# Altium schematic sheet Amplifier.SchDoc
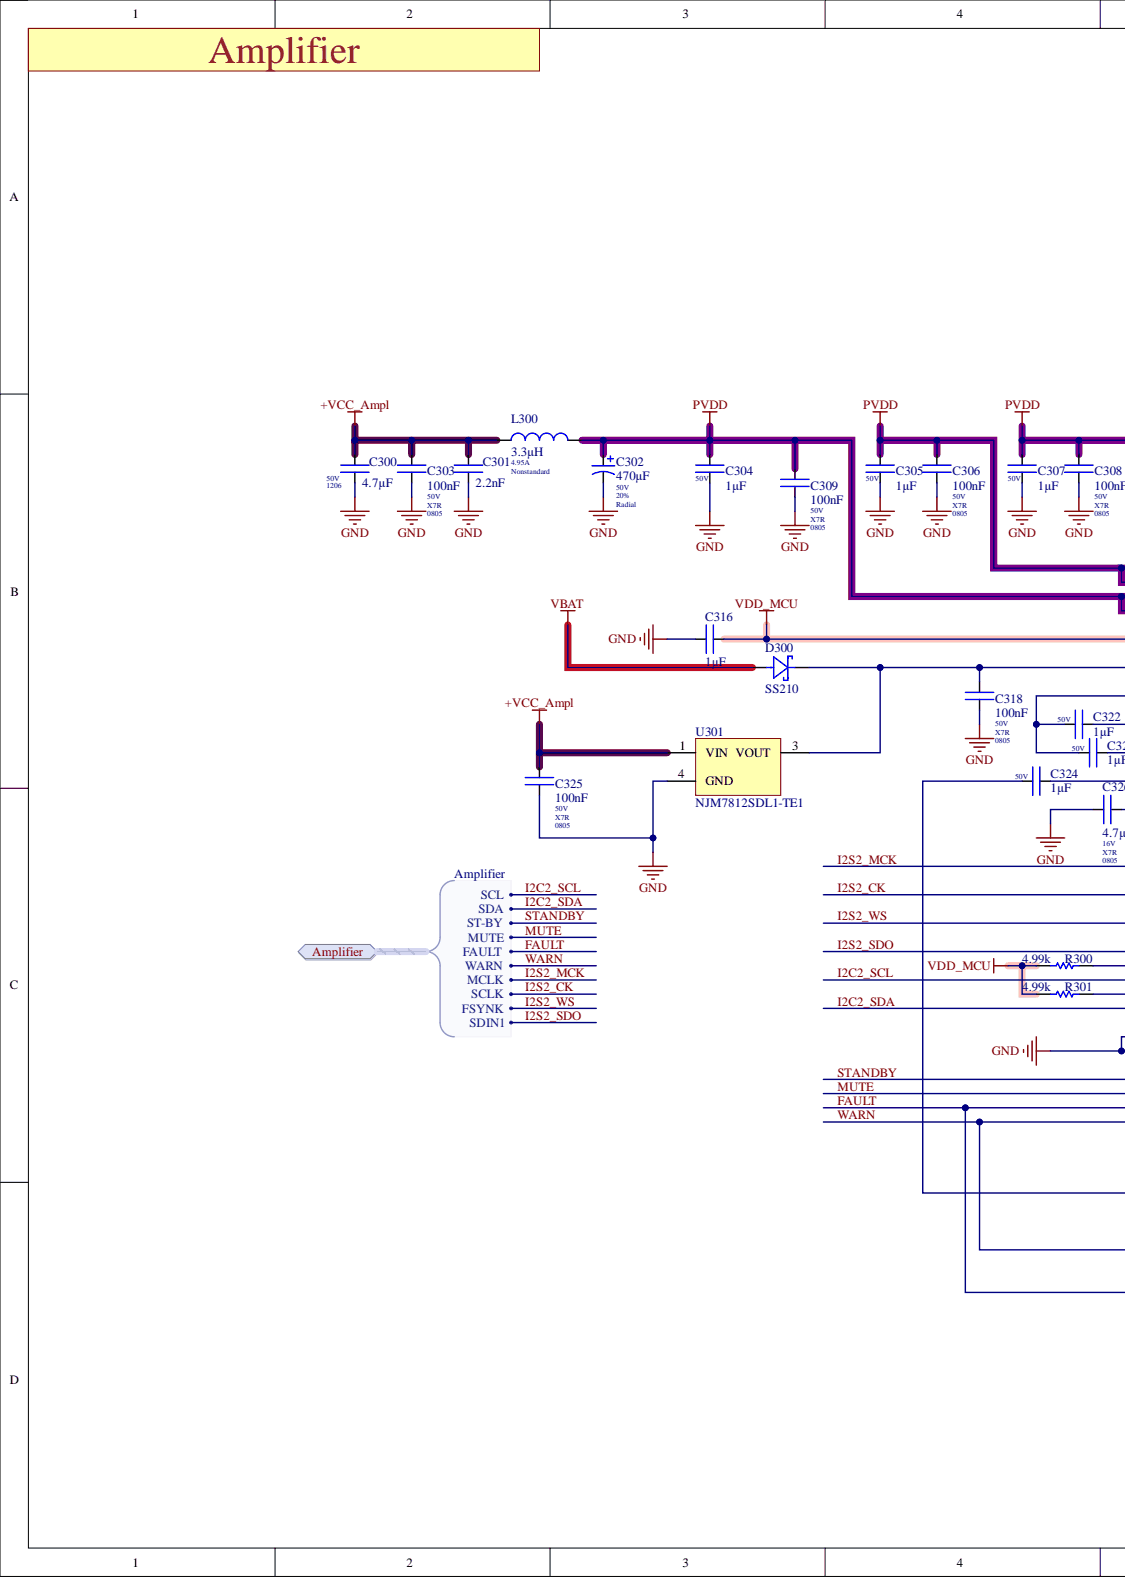
[diagram p0: Amplifier.SchDoc, left]
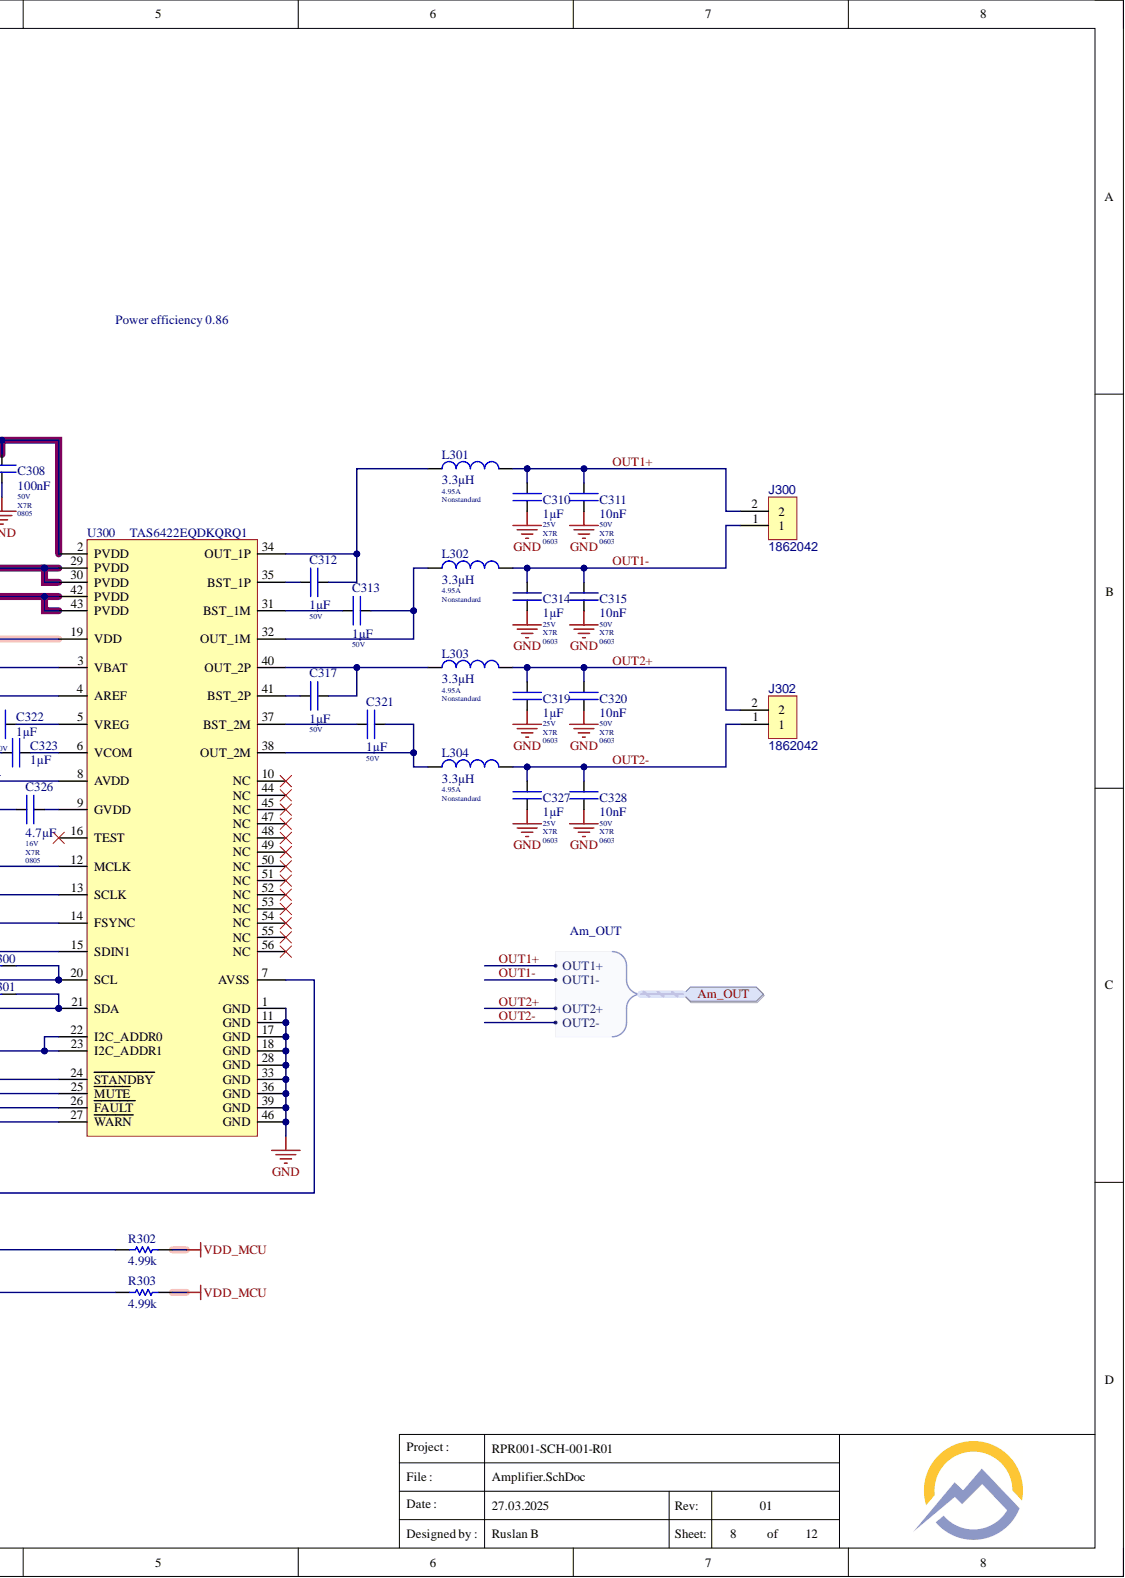
[diagram p1: Amplifier.SchDoc, right]

## Parts
C300  value 4.7µF  Case/Package: 1206  Voltage Rating: 50V  [B_Cap_1206_KEM]  (hidden comment: CL31B475KBHNNNE)
C301  value 2.2nF  [B_Cap_0402_KEM]  (hidden comment: CC0402JRX7R9BB222)
C302  value 470µF  Case/Package: Radial  Tolerance: 20%  Voltage Rating: 50V  [EMVA500ARA471MKG5S]  (hidden comment: EMVA500ARA471MKG5S)
C303  value 100nF  Case/Package: 0805  Dielectric: X7R  Voltage Rating: 50V  [B_Cap_0805_KEM]  (hidden comment: CC0805KRX7R9BB104)
C304  value 1µF  Voltage Rating: 50V  [B_Cap_0805_KEM]  (hidden comment: CL21B105KBFNNNG)
C305  value 1µF  Voltage Rating: 50V  [B_Cap_0805_KEM]  (hidden comment: CL21B105KBFNNNG)
C306  value 100nF  Case/Package: 0805  Dielectric: X7R  Voltage Rating: 50V  [B_Cap_0805_KEM]  (hidden comment: CC0805KRX7R9BB104)
C307  value 1µF  Voltage Rating: 50V  [B_Cap_0805_KEM]  (hidden comment: CL21B105KBFNNNG)
C308  value 100nF  Case/Package: 0805  Dielectric: X7R  Voltage Rating: 50V  [B_Cap_0805_KEM]  (hidden comment: CC0805KRX7R9BB104)
C309  value 100nF  Case/Package: 0805  Dielectric: X7R  Voltage Rating: 50V  [B_Cap_0805_KEM]  (hidden comment: CC0805KRX7R9BB104)
C310  value 1µF  Case/Package: 0603  Dielectric: X7R  Voltage Rating: 25V  [B_Cap_0603_KEM]  (hidden comment: CL10B105KA8NNNC)
C311  value 10nF  Case/Package: 0603  Dielectric: X7R  Voltage Rating: 50V  [B_Cap_0603_KEM]  (hidden comment: CC0603MRX7R9BB103)
C312  value 1µF  Voltage Rating: 50V  [B_Cap_0805_KEM]  (hidden comment: CL21B105KBFNNNG)
C313  value 1µF  Voltage Rating: 50V  [B_Cap_0805_KEM]  (hidden comment: CL21B105KBFNNNG)
C314  value 1µF  Case/Package: 0603  Dielectric: X7R  Voltage Rating: 25V  [B_Cap_0603_KEM]  (hidden comment: CL10B105KA8NNNC)
C315  value 10nF  Case/Package: 0603  Dielectric: X7R  Voltage Rating: 50V  [B_Cap_0603_KEM]  (hidden comment: CC0603MRX7R9BB103)
C316  value 1µF  [B_Cap_0805_KEM]  (hidden comment: CL21B105KBFNNNG)
C317  value 1µF  Voltage Rating: 50V  [B_Cap_0805_KEM]  (hidden comment: CL21B105KBFNNNG)
C318  value 100nF  Case/Package: 0805  Dielectric: X7R  Voltage Rating: 50V  [B_Cap_0805_KEM]  (hidden comment: CC0805KRX7R9BB104)
C319  value 1µF  Case/Package: 0603  Dielectric: X7R  Voltage Rating: 25V  [B_Cap_0603_KEM]  (hidden comment: CL10B105KA8NNNC)
C320  value 10nF  Case/Package: 0603  Dielectric: X7R  Voltage Rating: 50V  [B_Cap_0603_KEM]  (hidden comment: CC0603MRX7R9BB103)
C321  value 1µF  Voltage Rating: 50V  [B_Cap_0805_KEM]  (hidden comment: CL21B105KBFNNNG)
C322  value 1µF  Voltage Rating: 50V  [B_Cap_0805_KEM]  (hidden comment: CL21B105KBFNNNG)
C323  value 1µF  Voltage Rating: 50V  [B_Cap_0805_KEM]  (hidden comment: CL21B105KBFNNNG)
C324  value 1µF  Voltage Rating: 50V  [B_Cap_0805_KEM]  (hidden comment: CL21B105KBFNNNG)
C325  value 100nF  Case/Package: 0805  Dielectric: X7R  Voltage Rating: 50V  [B_Cap_0805_KEM]  (hidden comment: CC0805KRX7R9BB104)
C326  value 4.7µF  Voltage Rating: 16V  [B_Cap_0805_KEM]  (hidden comment: GRM21BR71C475KE51L)
C327  value 1µF  Case/Package: 0603  Dielectric: X7R  Voltage Rating: 25V  [B_Cap_0603_KEM]  (hidden comment: CL10B105KA8NNNC)
C328  value 10nF  Case/Package: 0603  Dielectric: X7R  Voltage Rating: 50V  [B_Cap_0603_KEM]  (hidden comment: CC0603MRX7R9BB103)
D300  SS210  [DO-214AA, SMB]
J300  1862042  [1862042]
J302  1862042  [1862042]
L300  value 3.3µH  [VLS6045EX]  (hidden comment: VLS6045EX-3R3N)
L301  value 3.3µH  Case/Package: Nonstandard  Current Rating: 4.95A  [VLS6045EX]  (hidden comment: VLS6045EX-3R3N)
L302  value 3.3µH  Case/Package: Nonstandard  Current Rating: 4.95A  [VLS6045EX]  (hidden comment: VLS6045EX-3R3N)
L303  value 3.3µH  Case/Package: Nonstandard  Current Rating: 4.95A  [VLS6045EX]  (hidden comment: VLS6045EX-3R3N)
L304  value 3.3µH  Case/Package: Nonstandard  Current Rating: 4.95A  [VLS6045EX]  (hidden comment: VLS6045EX-3R3N)
R300  value 4.99k  [Res_0402_YAG]  (hidden comment: RC0402FR-074K99P)
R301  value 4.99k  [Res_0402_YAG]  (hidden comment: RC0402FR-074K99P)
R302  value 4.99k  [Res_0402_YAG]  (hidden comment: RC0402FR-074K99P)
R303  value 4.99k  [Res_0402_YAG]  (hidden comment: RC0402FR-074K99P)
U300  TAS6422EQDKQRQ1  [TAS6422EQDKQRQ1]
U301  NJM7812SDL1-TE1  [TO-252-3, DPak (2 Leads + Tab), SC-63]

## Ports
Am_OUT, Am_OUT.OUT1+, Am_OUT.OUT1-, Am_OUT.OUT2+, Am_OUT.OUT2-, Amplifier, Amplifier.FAULT, Amplifier.FSYNK, Amplifier.MCLK, Amplifier.MUTE, Amplifier.SCL, Amplifier.SCLK, Amplifier.SDA, Amplifier.SDIN1, Amplifier.ST-BY, Amplifier.WARN

## Nets
+VCC_Ampl: C300.2 C301.2 C303.2 C325.2 L300.1 U301.1
GND: C300.1 C301.1 C302.2 C303.1 C304.1 C305.1 C306.1 C307.1 C308.1 C309.1 C310.1 C311.1 C314.1 C315.1 C316.1 C318.1 C319.1 C320.1 C325.1 C326.2 C327.1 C328.1 U300.1(GND) U300.11(GND) U300.17(GND) U300.18(GND) U300.22(I2C_ADDR0) U300.23(I2C_ADDR1) U300.28(GND) U300.33(GND) U300.36(GND) U300.39(GND) U300.46(GND) U301.4
PVDD: C302.1 C304.2 C305.2 C306.2 C307.2 C308.2 C309.2 L300.2 U300.2(PVDD) U300.29(PVDD) U300.30(PVDD) U300.42(PVDD) U300.43(PVDD)
VBAT: D300.2
VDD_MCU: C316.2 R300.1 R301.1 R302.2 R303.2 U300.19(VDD)
FAULT: R303.1 U300.26(FAULT) ; port Amplifier
I2C2_SCL: R300.2 U300.20(SCL) ; port Amplifier
I2C2_SDA: R301.2 U300.21(SDA) ; port Amplifier
I2S2_CK: U300.13(SCLK) ; port Amplifier
I2S2_MCK: U300.12(MCLK) ; port Amplifier
I2S2_SDO: U300.15(SDIN1) ; port Amplifier
I2S2_WS: U300.14(FSYNC) ; port Amplifier
MUTE: U300.25(MUTE) ; port Amplifier
OUT1+: C310.2 C311.2 J300.2 L301.2 ; port Am_OUT
OUT1-: C314.2 C315.2 J300.1 L302.2 ; port Am_OUT
OUT2+: C319.2 C320.2 J302.2 L303.2 ; port Am_OUT
OUT2-: C327.2 C328.2 J302.1 L304.2 ; port Am_OUT
STANDBY: U300.24(STANDBY) ; port Amplifier
WARN: R302.1 U300.27(WARN) ; port Amplifier
NetC312_1: C312.1 L301.1 U300.34(OUT_1P)
NetC312_2: C312.2 U300.35(BST_1P)
NetC313_1: C313.1 L302.1 U300.32(OUT_1M)
NetC313_2: C313.2 U300.31(BST_1M)
NetC317_1: C317.1 L303.1 U300.40(OUT_2P)
NetC317_2: C317.2 U300.41(BST_2P)
NetC318_2: C318.2 D300.1 U300.3(VBAT) U301.3
NetC321_1: C321.1 L304.1 U300.38(OUT_2M)
NetC321_2: C321.2 U300.37(BST_2M)
NetC322_1: C322.1 C323.1 U300.4(AREF)
NetC322_2: C322.2 U300.5(VREG)
NetC323_2: C323.2 U300.6(VCOM)
NetC324_1: C324.1 U300.7(AVSS)
NetC324_2: C324.2 U300.8(AVDD)
NetC326_1: C326.1 U300.9(GVDD)

Not connected: U300 10(NC) 16(TEST) 44(NC) 45(NC) 47(NC) 48(NC) 49(NC) 50(NC) 51(NC) 52(NC) 53(NC) 54(NC) 55(NC) 56(NC)

## Library data of the parts
C300 = CMP-CAP-CER-00206-11: CAP CER 4.7UF 50V X7R 1206; Manufacturer 1: Samsung; Tolerance: ±10%; Component Kind: Standard; ComponentLink1Description: Datasheet; ComponentLink1URL: https://media.digikey.com/pdf/Data%20Sheets/Samsung%20PDFs/CL_Series_MLCC_ds.pdf; Library Name: <Company> Server; Manufacturer Part Number 1: CL31B475KBHNNNE
C301 = CMP-CAP-CER-00157-17: CAP CER 2200PF 50V X7R 0402; Manufacturer 1: Yageo; Tolerance: ±5%; Component Kind: Standard; ComponentLink1Description: Datasheet; ComponentLink1URL: https://www.yageo.com/upload/media/product/productsearch/datasheet/mlcc/UPY-GPHC_X7R_6.3V-to-50V_18.pdf; Library Name: <Company> Server; Manufacturer Part Number 1: CC0402JRX7R9BB222
C302 = CMP-CAP-ALU-00015-7: CAP ALUM 470UF 20% 50V SMD; Manufacturer 1: United Chemi-Con; Ripple Current: 740mA; Component Kind: Standard; ComponentLink1Description: Datasheet; ComponentLink1URL: https://www.chemi-con.co.jp/products/relatedfiles/capacitor/catalog/MVARA-e.PDF; Library Name: <Company> Server; Manufacturer Part Number 1: EMVA500ARA471MKG5S; Mount: Surface Mount
C303 C306 C308 C309 C318 C325 = CMP-CAP-CER-00029-14: Multilayer Ceramic Capacitor, 0.1 uF, 50 V, ï¿½ 10%, X7R, 0805 [2012 Metric]; Manufacturer 1: YAGEO; Component Kind: Standard; Library Name: <Company> Server; Manufacturer Part Number 1: CC0805KRX7R9BB104; Tolerance: 10%
C304 C305 C307 C312 C313 C316 C317 C321-C324 = CMP-CAP-CER-00135-12: CAP CER 1UF 50V X7R 0805; Manufacturer 1: Samsung; Tolerance: ±10%; Component Kind: Standard; ComponentLink1Description: Datasheet; ComponentLink1URL: http://www.samsungsem.com/kr/support/product-search/mlcc/CL21B105KBFNNNG.jsp; Library Name: <Company> Server; Manufacturer Part Number 1: CL21B105KBFNNNG
C310 C314 C319 C327 = CMP-CAP-CER-00132-13: CL10B105KA8NNNC Samsung Ceramic Capacitor MLCC 1uF10% 25V X7R SMD 0603 (1608 Metric) RoHS; Manufacturer 1: Samsung Electro-Mechanics; Tolerance: 10%; Component Kind: Standard; Library Name: <Company> Server; Manufacturer Part Number 1: CL10B105KA8NNNC
C311 C315 C320 C328 = CMP-CAP-CER-00261-3: 0603 10 nF 50 V ±20% Tolerance X7R SMT Multilayer Ceramic Capacitor; Manufacturer 1: Yageo; Component Kind: Standard; Library Name: <Company> Server; Manufacturer Part Number 1: CC0603MRX7R9BB103; Tolerance: 20%
C326 = CMP-CAP-CER-00204-11: CAP CER 4.7UF 16V X7R 0805; Manufacturer 1: Murata; Tolerance: ±10%; Value: 4.7µF; Case/Package: 0805; Component Kind: Standard; ComponentLink1Description: Datasheet; ComponentLink1URL: https://search.murata.co.jp/Ceramy/image/img/A01X/G101/ENG/GRM21BR71C475KE51-01.pdf; Dielectric: X7R; Library Name: <Company> Server; Manufacturer Part Number 1: GRM21BR71C475KE51L
D300 = CMP-DIO-SCH-00037-8: DIODE SCHOTTKY 100V 2A SMA; Manufacturer 1: Taiwan Semiconductor; Forward Voltage: 850mV; Max Reverse Current: 100µA; Average Rectified Current: 2A; Case/Package: DO-214AA; Component Kind: Standard; ComponentLink1Description: Datasheet; ComponentLink1URL: http://www.smc-diodes.com/propdf/SS22A%20THRU%20SS225A%20N1816%20REV.A.pdf; Forward Current: 2A; Library Name: <Company> Server; Manufacturer Part Number 1: SS210; Max Reverse Voltage (DC): 100V
J300 J302 = CMP-CON-TBL-00026-3: TERM BLOCK 2POS 45DEG 3.5MM PCB; Manufacturer 1: Phoenix Contact; Current Rating: 8A; Number of Contacts: 2; Pitch: 3.50mm; Voltage Rating: 300V; Component Kind: Standard; ComponentLink1Description: Datasheet; ComponentLink1URL: https://www.phoenixcontact.com/pxc-oc-itemdetail-pdf-web/eshop?lineItemType=LineItem&UID=1862042; Library Name: <Company> Server; Manufacturer Part Number 1: 1862042; Mount: Through Hole; Number of Rows: 1; Orientation: 45° (135°) Angle with Board
L300-L304 = CMP-IND-00075-9: FIXED IND 3.3UH 4.95A 30 MOHM; Manufacturer 1: TDK; DC Resistance (DCR): 23m; Shielding: Shielded; Tolerance: 30%; Component Kind: Standard; ComponentLink1Description: Datasheet; ComponentLink1URL: https://product.tdk.com/info/en/catalog/datasheets/inductor_commercial_power_vls6045ex_en.pdf; Library Name: <Company> Server; Manufacturer Part Number 1: VLS6045EX-3R3N
  L300: Case/Package: Nonstandard; Current Rating: 4.95A
R300-R303 = CMP-RES-SMD-00334-12: RES SMD 4.99K OHM 1% 1/16W 0402; Manufacturer 1: Yageo; Temperature Coefficient: ±100ppm/℃; Component Kind: Standard; ComponentLink1Description: Datasheet; ComponentLink1URL: https://www.yageo.com/upload/media/product/productsearch/datasheet/rchip/PYu-RC_51_RoHS_P_1.pdf; Library Name: <Company> Server; Manufacturer Part Number 1: RC0402FR-074K99P
U300 = CMP-AUD-AMP-00000-3: Audio Amplifier, 75W, 2 Channel(s), 1 Func, PDSO56; Manufacturer 1: Texas Instruments; Interface: I2S; Max Supply Voltage: 26.4V; Min Supply Voltage: 4.5V; Number of Channels: 2; Case/Package: 56-HSSOP; Component Kind: Standard; Library Name: <Company> Server; Manufacturer Part Number 1: TAS6422EQDKQRQ1; Mount: Surface Mount; Output Power: 75W
U301 = CMP-POW-VOLR-LIN-00028-1: IC Reg Linear 12V 1.5A TO252-3; Manufacturer 1: Nisshinbo Micro Devices Inc.; Min Input Voltage: 35V; Output Type: Fixed; Case/Package: DPAK; Component Kind: Standard; Library Name: <Company> Server; Manufacturer Part Number 1: NJM7812SDL1-TE1
